# Altium schematic sheet FTDI-Toolkit.SchDoc(FTDI-Toolkit)
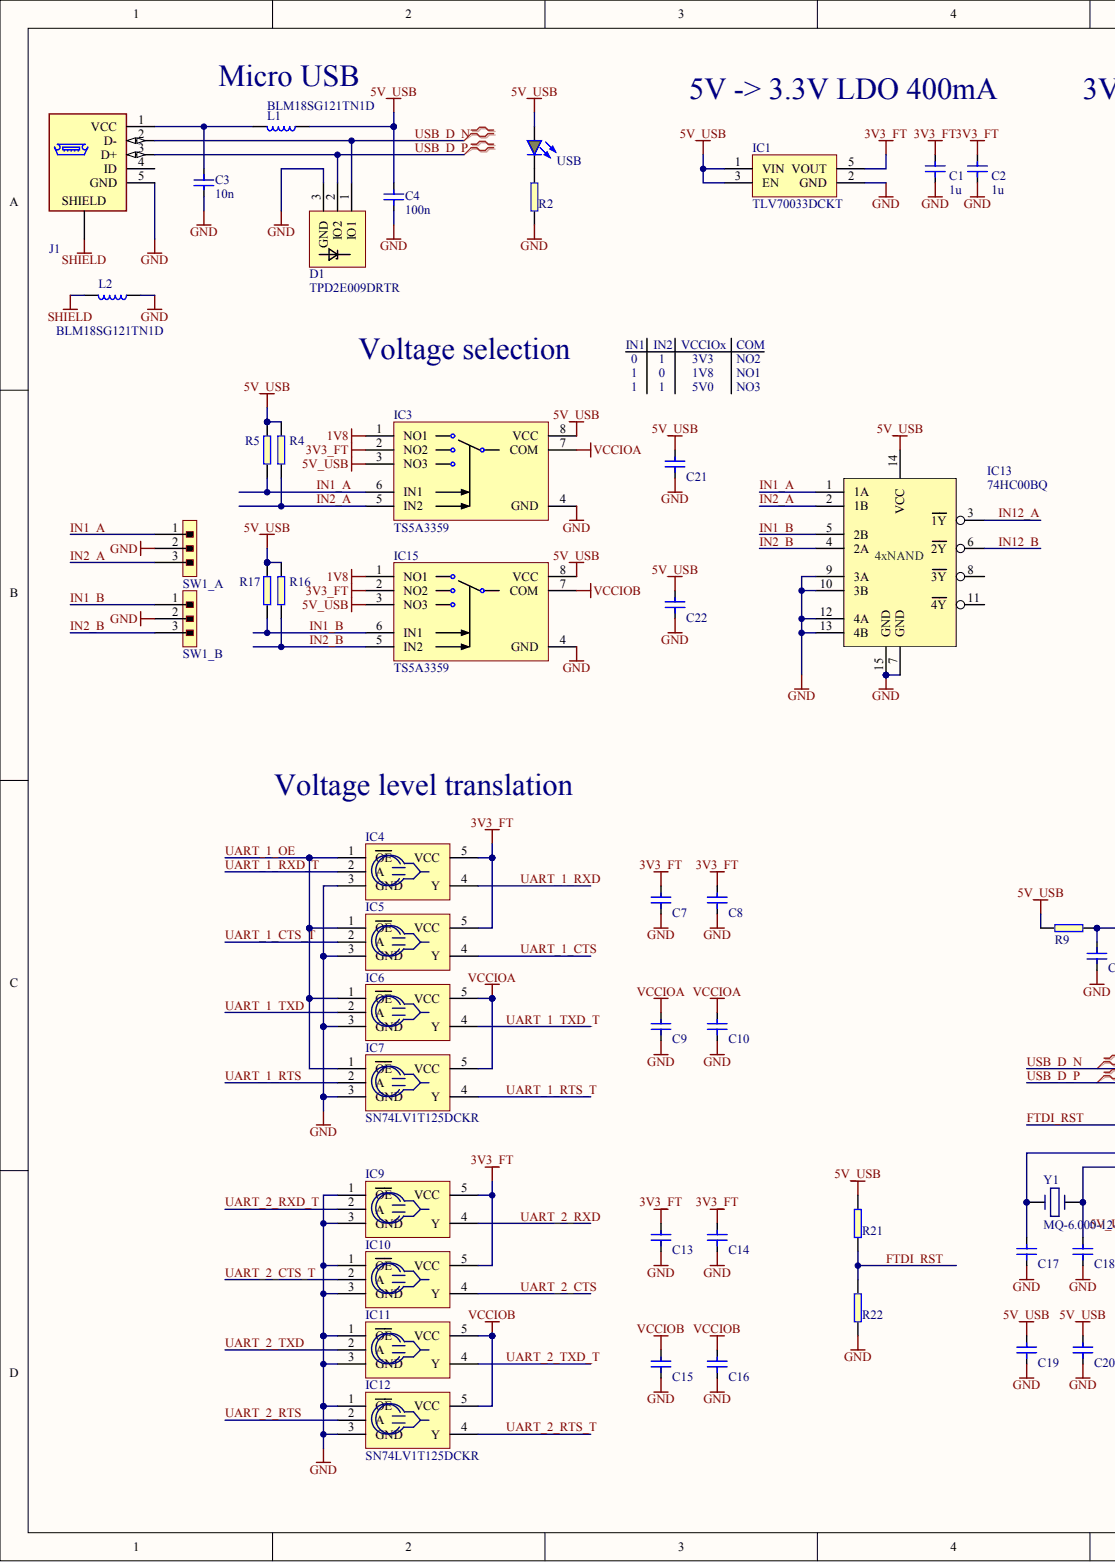
[diagram p0: FTDI-Toolkit.SchDoc, left]
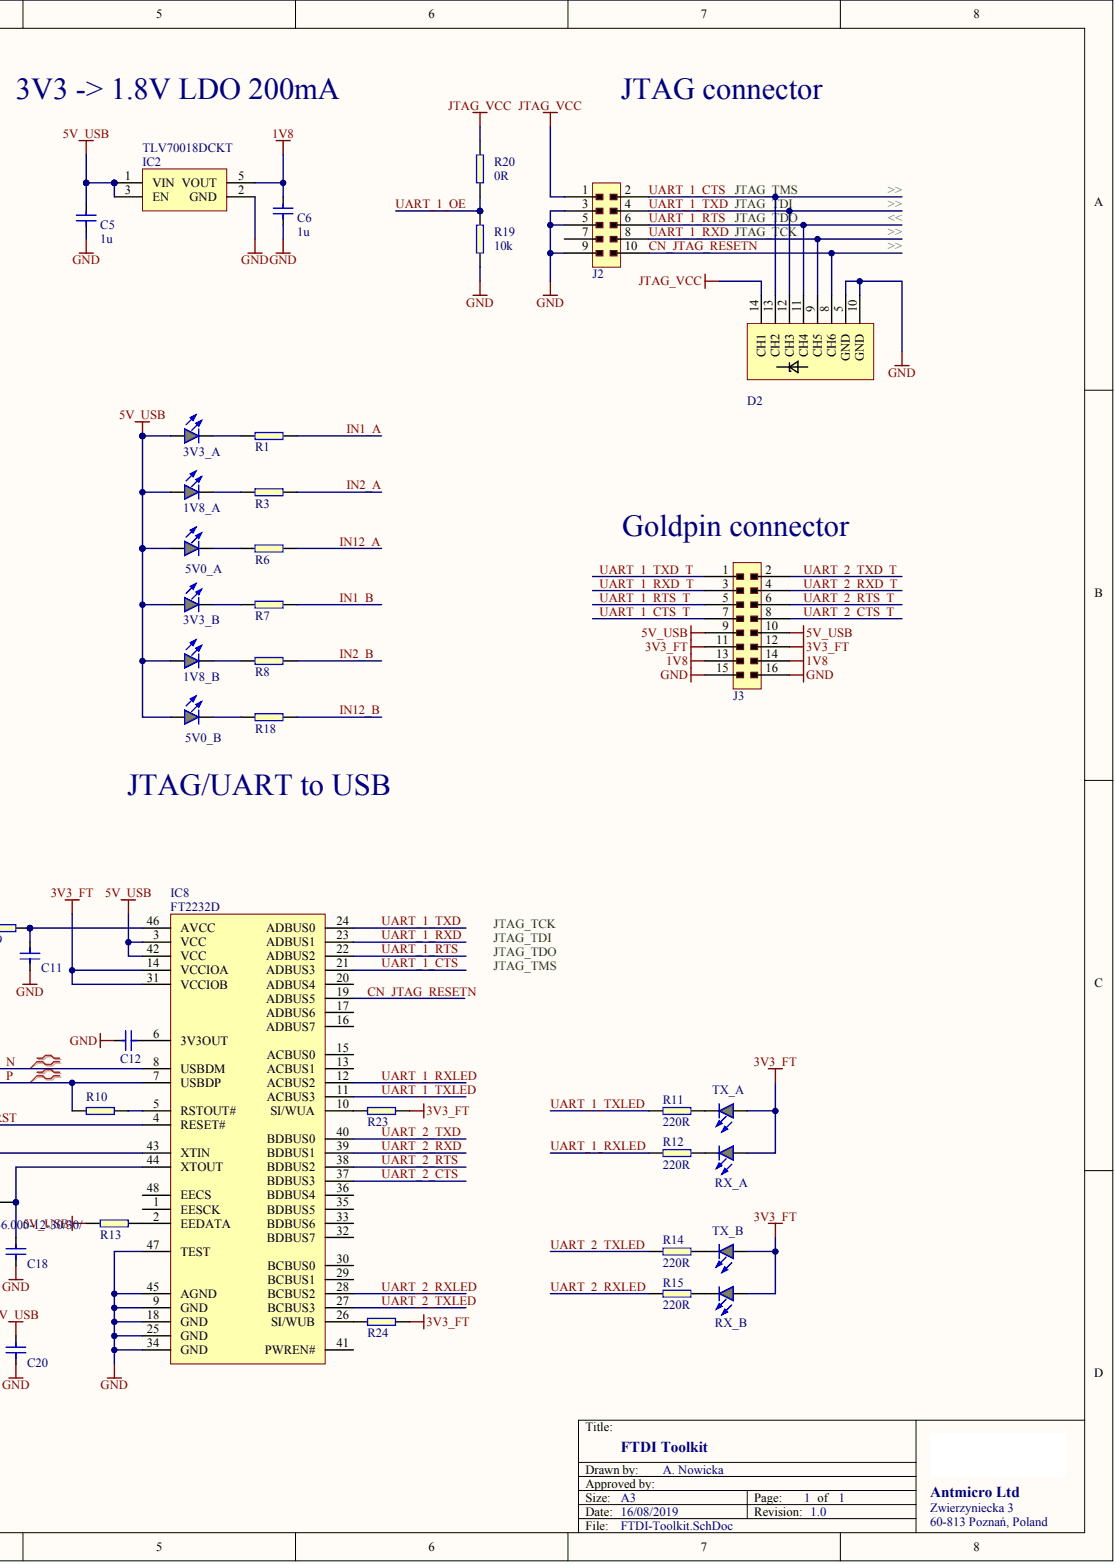
[diagram p1: FTDI-Toolkit.SchDoc, right]

## Parts
1V8_A  KPT-1608SGC  (value not drawn)
1V8_B  KPT-1608SGC  (value not drawn)
3V3_A  KPT-1608SGC  (value not drawn)
3V3_B  KPT-1608SGC  (value not drawn)
5V0_A  KPT-1608SGC  (value not drawn)
5V0_B  KPT-1608SGC  (value not drawn)
C1  1u
C2  1u
C3  10n
C4  100n
C5  1u
C6  1u
C7  100n  (value not drawn)
C8  100n  (value not drawn)
C9  100n  (value not drawn)
C10  100n  (value not drawn)
C11  100n  (value not drawn)
C12  100n  (value not drawn)
C13  100n  (value not drawn)
C14  100n  (value not drawn)
C15  100n  (value not drawn)
C16  100n  (value not drawn)
C17  27p  (value not drawn)
C18  27p  (value not drawn)
C19  100n  (value not drawn)
C20  100n  (value not drawn)
C21  100n  (value not drawn)
C22  100n  (value not drawn)
D1  TPD2E009DRTR
D2  TPD6E05U06  (value not drawn)
IC1  TLV70033DCKT
IC2  TLV70018DCKT
IC3  TS5A3359
IC4  SN74LV1T125DCKR  (value not drawn)
IC5  SN74LV1T125DCKR  (value not drawn)
IC6  SN74LV1T125DCKR  (value not drawn)
IC7  SN74LV1T125DCKR
IC8  FT2232D
IC9  SN74LV1T125DCKR  (value not drawn)
IC10  SN74LV1T125DCKR  (value not drawn)
IC11  SN74LV1T125DCKR  (value not drawn)
IC12  SN74LV1T125DCKR
IC13  74HC00BQ
IC15  TS5A3359
J1  (no value)
J2  SHF-105-01-L-D-SM  (value not drawn)
J3  MH_2X08_2.54_RA  (value not drawn)
L1  BLM18SG121TN1D
L2  BLM18SG121TN1D
R1  220R  (value not drawn)
R2  470R  (value not drawn)
R3  220R  (value not drawn)
R4  10k  (value not drawn)
R5  10k  (value not drawn)
R6  220R  (value not drawn)
R7  220R  (value not drawn)
R8  220R  (value not drawn)
R9  470R  (value not drawn)
R10  1k54  (value not drawn)
R11  220R
R12  220R
R13  10k  (value not drawn)
R14  220R
R15  220R
R16  10k  (value not drawn)
R17  10k  (value not drawn)
R18  220R  (value not drawn)
R19  10k
R20  0R
R21  4k7  (value not drawn)
R22  10k  (value not drawn)
R23  0R  (value not drawn)
R24  0R  (value not drawn)
RX_A  KPT-1608SGC  (value not drawn)
RX_B  KPT-1608SGC  (value not drawn)
SW1_A  MH_1X03_2.54  (value not drawn)
SW1_B  MH_1X03_2.54  (value not drawn)
TX_A  KPT-1608SGC  (value not drawn)
TX_B  KPT-1608SGC  (value not drawn)
USB  KPT-1608SGC  (value not drawn)
Y1  MQ-6.000-12-30/30/

## Nets
1V8: C6.2 IC2.5(VOUT) IC3.1(NO1) IC15.1(NO1) J3.13 J3.14
3V3_FT: C1.2 C2.2 C7.2 C8.2 C13.2 C14.2 IC1.5(VOUT) IC3.2(NO2) IC4.5(VCC) IC5.5(VCC) IC8.14(VCCIOA) IC8.31(VCCIOB) IC9.5(VCC) IC10.5(VCC) IC15.2(NO2) J3.11 J3.12 R23.2 R24.2 RX_A.A RX_B.A TX_A.A TX_B.A
5V_USB: 1V8_A.A 1V8_B.A 3V3_A.A 3V3_B.A 5V0_A.A 5V0_B.A C4.2 C5.2 C19.2 C20.2 C21.2 C22.2 IC1.1(VIN) IC1.3(EN) IC2.1(VIN) IC2.3(EN) IC3.3(NO3) IC3.8(VCC) IC8.3(VCC) IC8.42(VCC) IC13.14(VCC) IC15.3(NO3) IC15.8(VCC) J3.9 J3.10 L1.1 R4.2 R5.2 R9.1 R13.1 R16.2 R17.2 R21.2 USB.A
GND: C1.1 C2.1 C3.2 C4.1 C5.1 C6.1 C7.1 C8.1 C9.1 C10.1 C11.2 C12.2 C13.1 C14.1 C15.1 C16.1 C17.1 C18.1 C19.1 C20.1 C21.1 C22.1 D1.3 D2.5(GND) D2.10(GND) IC1.2(GND) IC2.2(GND) IC3.4(GND) IC4.3(GND) IC5.3(GND) IC6.3(GND) IC7.3(GND) IC8.9(GND) IC8.18(GND) IC8.25(GND) IC8.34(GND) IC8.45(AGND) IC8.47(TEST) IC9.1(OE) IC9.3(GND) IC10.1(OE) IC10.3(GND) IC11.1(OE) IC11.3(GND) IC12.1(OE) IC12.3(GND) IC13.7(GND) IC13.9(3A) IC13.10(3B) IC13.12(4A) IC13.13(4B) IC13.15(GND) IC15.4(GND) J1.5(GND) J2.3 J2.5 J2.9 J3.15 J3.16 L2.1 R2.1 R19.1 R22.1 SW1_A.2 SW1_B.2
JTAG_VCC: D2.14(CH1) J2.1 R20.2
SHIELD: J1.S L2.2
VCCIOA: C9.2 C10.2 IC3.7(COM) IC6.5(VCC) IC7.5(VCC)
VCCIOB: C15.2 C16.2 IC11.5(VCC) IC12.5(VCC) IC15.7(COM)
CN_JTAG_RESETN: D2.8(CH6) IC8.19(ADBUS5) J2.10
FTDI_RST: IC8.4(RESET#) R21.1 R22.2
IN1_A: IC3.6(IN1) IC13.1(1A) R1.2 R5.1 SW1_A.1
IN1_B: IC13.5(2B) IC15.6(IN1) R7.2 R17.1 SW1_B.1
IN2_A: IC3.5(IN2) IC13.2(1B) R3.2 R4.1 SW1_A.3
IN2_B: IC13.4(2A) IC15.5(IN2) R8.2 R16.1 SW1_B.3
IN12_A: IC13.3(1Y) R6.2
IN12_B: IC13.6(2Y) R18.2
UART_1_CTS: D2.13(CH2) IC5.4(Y) IC8.21(ADBUS3) J2.2
UART_1_CTS_T: IC5.2(A) J3.7
UART_1_OE: IC4.1(OE) IC5.1(OE) IC6.1(OE) IC7.1(OE) R19.2 R20.1
UART_1_RTS: D2.11(CH4) IC7.2(A) IC8.22(ADBUS2) J2.6
UART_1_RTS_T: IC7.4(Y) J3.5
UART_1_RXD: D2.9(CH5) IC4.4(Y) IC8.23(ADBUS1) J2.8
UART_1_RXD_T: IC4.2(A) J3.3
UART_1_RXLED: IC8.12(ACBUS2) R12.1
UART_1_TXD: D2.12(CH3) IC6.2(A) IC8.24(ADBUS0) J2.4
UART_1_TXD_T: IC6.4(Y) J3.1
UART_1_TXLED: IC8.11(ACBUS3) R11.1
UART_2_CTS: IC8.37(BDBUS3) IC10.4(Y)
UART_2_CTS_T: IC10.2(A) J3.8
UART_2_RTS: IC8.38(BDBUS2) IC12.2(A)
UART_2_RTS_T: IC12.4(Y) J3.6
UART_2_RXD: IC8.39(BDBUS1) IC9.4(Y)
UART_2_RXD_T: IC9.2(A) J3.4
UART_2_RXLED: IC8.28(BCBUS2) R15.1
UART_2_TXD: IC8.40(BDBUS0) IC11.2(A)
UART_2_TXD_T: IC11.4(Y) J3.2
UART_2_TXLED: IC8.27(BCBUS3) R14.1
USB_D_N: D1.1 IC8.8(USBDM) J1.2(D-)
USB_D_P: D1.2 IC8.7(USBDP) J1.3(D+) R10.1
Net1V8_A_K: 1V8_A.K R3.1
Net1V8_B_K: 1V8_B.K R8.1
Net3V3_A_K: 3V3_A.K R1.1
Net3V3_B_K: 3V3_B.K R7.1
Net5V0_A_K: 5V0_A.K R6.1
Net5V0_B_K: 5V0_B.K R18.1
NetC3_1: C3.1 J1.1(VCC) L1.2
NetC11_1: C11.1 IC8.46(AVCC) R9.2
NetC12_1: C12.1 IC8.6(3V3OUT)
NetC17_2: C17.2 IC8.43(XTIN) Y1.1
NetC18_2: C18.2 IC8.44(XTOUT) Y1.3
NetIC8_2: IC8.2(EEDATA) R13.2
NetIC8_5: IC8.5(RSTOUT#) R10.2
NetIC8_10: IC8.10(SI/WUA) R23.1
NetIC8_26: IC8.26(SI/WUB) R24.1
NetR2_2: R2.2 USB.K
NetR11_2: R11.2 TX_A.K
NetR12_2: R12.2 RX_A.K
NetR14_2: R14.2 TX_B.K
NetR15_2: R15.2 RX_B.K
N00052:

Not connected: IC8 1(EESCK) 13(ACBUS1) 15(ACBUS0) 16(ADBUS7) 17(ADBUS6) 20(ADBUS4) 29(BCBUS1) 30(BCBUS0) 32(BDBUS7) 33(BDBUS6) 35(BDBUS5) 36(BDBUS4) 41(PWREN#) 48(EECS); IC13 8(3Y) 11(4Y); J1 4(ID); J2 7

## Library data of the parts
1V8_A 1V8_B 3V3_A 3V3_B 5V0_A 5V0_B = (no symbol name): PartNumber: LED_G; Manufacturer: KINGBRIGHT; Value: KPT-1608SGC; Supplier1: Farnell; SupplierPartNumber1: 2099223
C1 C2 C5 C6 = (no symbol name): PartNumber: C_1u_0603; Manufacturer: KEMET; Value: 1u; Supplier1: Farnell; SupplierPartNumber1: 2821145
C3 = (no symbol name): Part Number: C_10n_0402; Manufacturer: AVX; Part Symbol: 04025G103ZAT2A; Value: 10n; Supplier 1: Farnell; Supplier Part Number 1: 2332531
C4 = (no symbol name): Part Number: C_100n_0402; Manufacturer: MULTICOMP; Part Symbol: MC0402X104M100CT; Value: 100n; Supplier 1: Farnell; Supplier Part Number 1: 2309011
C7-C16 C19 C20 = (no symbol name): PartNumber: C_100n_0402; Manufacturer: TDK; Value: 100n; Supplier1: Farnell; SupplierPartNumber1: 2525006
C17 C18 = (no symbol name): PartNumber: C_27p_0402; Manufacturer: WALSIN; Value: 27p; Supplier1: Farnell; SupplierPartNumber1: 2496801
C21 C22 = (no symbol name): PartNumber: C_100n_0603; Manufacturer: AVX; Value: 100n; Supplier1: Farnell; SupplierPartNumber1: 2496834
D1 IC3-IC7 IC9-IC12 IC15 = (no symbol name): Manufacturer: Texas Instruments
  D1: Part Number: TPD2E009DRTR; Value: TPD2E009DRTR; Supplier 1: Digi-Key; Supplier Part Number 1: 296-25410-1-ND; Supplier 2: Farnell; Supplier Part Number 2: 2459951; Part Symbol: TPD2E009DRTR
  IC3 IC15: PartNumber: TS5A3359; Value: TS5A3359; Supplier1: Farnell; SupplierPartNumber1: 1755411
  IC4-IC7 IC9-IC12: PartNumber: SN74LV1T125DCKR; Value: SN74LV1T125DCKR; Supplier1: Farnell; SupplierPartNumber1: 2499911
D2 = (no symbol name): PartNumber: TPD6E05U06_PASS; Manufacturer: Texas Instruments; Value: TPD6E05U06; Supplier1: Digi-Key; SupplierPartNumber1: 296-39349-1-ND
IC1 IC2 = (no symbol name): Manufacturer: TEXAS INSTRUMENTS; Supplier1: Farnell
  IC1: PartNumber: TLV70033DCKT; Value: TLV70033DCKT; SupplierPartNumber1: 1815750
  IC2: PartNumber: TLV70018DCKT; Value: TLV70018DCKT; SupplierPartNumber1: 1778221
IC13 = (no symbol name): Manufacturer: NEXPERIA; PartNumber: 74HC00BQ; Value: 74HC00BQ; Supplier1: Digi-Key; SupplierPartNumber1: 1727-3213-1-ND
J1 = (no symbol name): Part Number: WE_629105150521; Manufacturer: WURTH ELEKTRONIK; Part Symbol: 629105150521; Supplier Part Number 1: 2470822; Supplier 1: Farnell
J2 = (no symbol name): Manufacturer: Samtec; Pins number: 20; Part Number: SHF-105-01-L-D-SM; Supplier Part Number 1: 1885915; Value: SHF-105-01-L-D-SM; Supplier 1: Farnell; Part Symbol: SHF-105-01-L-D-SM
L1 L2 = (no symbol name): Manufacturer: MURATA; Rated Current: 3A; Part Number: BLM18SG121TN1D; Value: BLM18SG121TN1D; Supplier 1: Farnell; Supplier Part Number 1: 1515752; Part Symbol: BLM18SG121TN1D
R1 R3 R6-R8 R11 R12 R14 R15 R18 = (no symbol name): PartNumber: R_220R_0402; Manufacturer: TE CONNECTIVITY / NEOHM; Value: 220R; Supplier1: Farnell; SupplierPartNumber1: 1174148
R2 = (no symbol name): Manufacturer: VISHAY; PartNumber: R_470R_0402; Value: 470R; Supplier1: Farnell; SupplierPartNumber1: 2140742
R4 R5 R13 R16 R17 R19 R22 = (no symbol name): PartNumber: R_10k_0402; Manufacturer: VISHAY; Tolerance: 1%; Value: 10k; Supplier1: Farnell; SupplierPartNumber1: 1738864
R9 = (no symbol name): PartNumber: R_470R_0402; Manufacturer: VISHAY; Value: 470R; Supplier1: Farnell; SupplierPartNumber1: 2140742
R10 = (no symbol name): PartNumber: R_1k54_0402; Manufacturer: VISHAY; Tolerance: 1%; Value: 1k54; Supplier1: Farnell; SupplierPartNumber1: 2140790
R20 = (no symbol name): PartNumber: R_0R_0402; Manufacturer: PANASONIC; Value: 0R; Supplier1: Farnell; SupplierPartNumber1: 2059190
R21 = (no symbol name): PartNumber: R_4k7_0402; Manufacturer: PANASONIC ELECTRONIC COMPONENTS; Value: 4k7; Supplier1: Farnell; SupplierPartNumber1: 2059234
R23 R24 = (no symbol name): PartNumber: R_0R_0402; Manufacturer: MULTICOMP; Value: 0R; Supplier1: Farnell; SupplierPartNumber1: 2072513
RX_A RX_B TX_A TX_B USB = (no symbol name): Part Number: LED_G; Manufacturer: KINGBRIGHT; Value: KPT-1608SGC; Supplier 1: Farnell; Supplier Part Number 1: 2099223; Part Symbol: KPT-1608SGC
SW1_A SW1_B = (no symbol name): Manufacturer: HARWIN; PartNumber: MH_1X03_2.54; Value: MH_1X03_2.54; Supplier1: Farnell; SupplierPartNumber1: 1022248
Y1 = (no symbol name): PartNumber: CRYSTAL_6_MHz_SMD; Manufacturer: MERCURY UNITED ELECTRONICS; PartSymbol: MQ-6.000-12-30/30/-40+85; Value: MQ-6.000-12-30/30/; Supplier1: Farnell; SupplierPartNumber1: 2509174
IC8 J3 = (no symbol name)
  IC8: Part Number: FT2232D; Value: FT2232D; Supplier 1: Farnell; Supplier Part Number 1: 1615843
  J3: PartNumber: MH_2X08_2.54_RA; Value: MH_2X08_2.54_RA
